# Altium schematic sheet Power.SchDoc
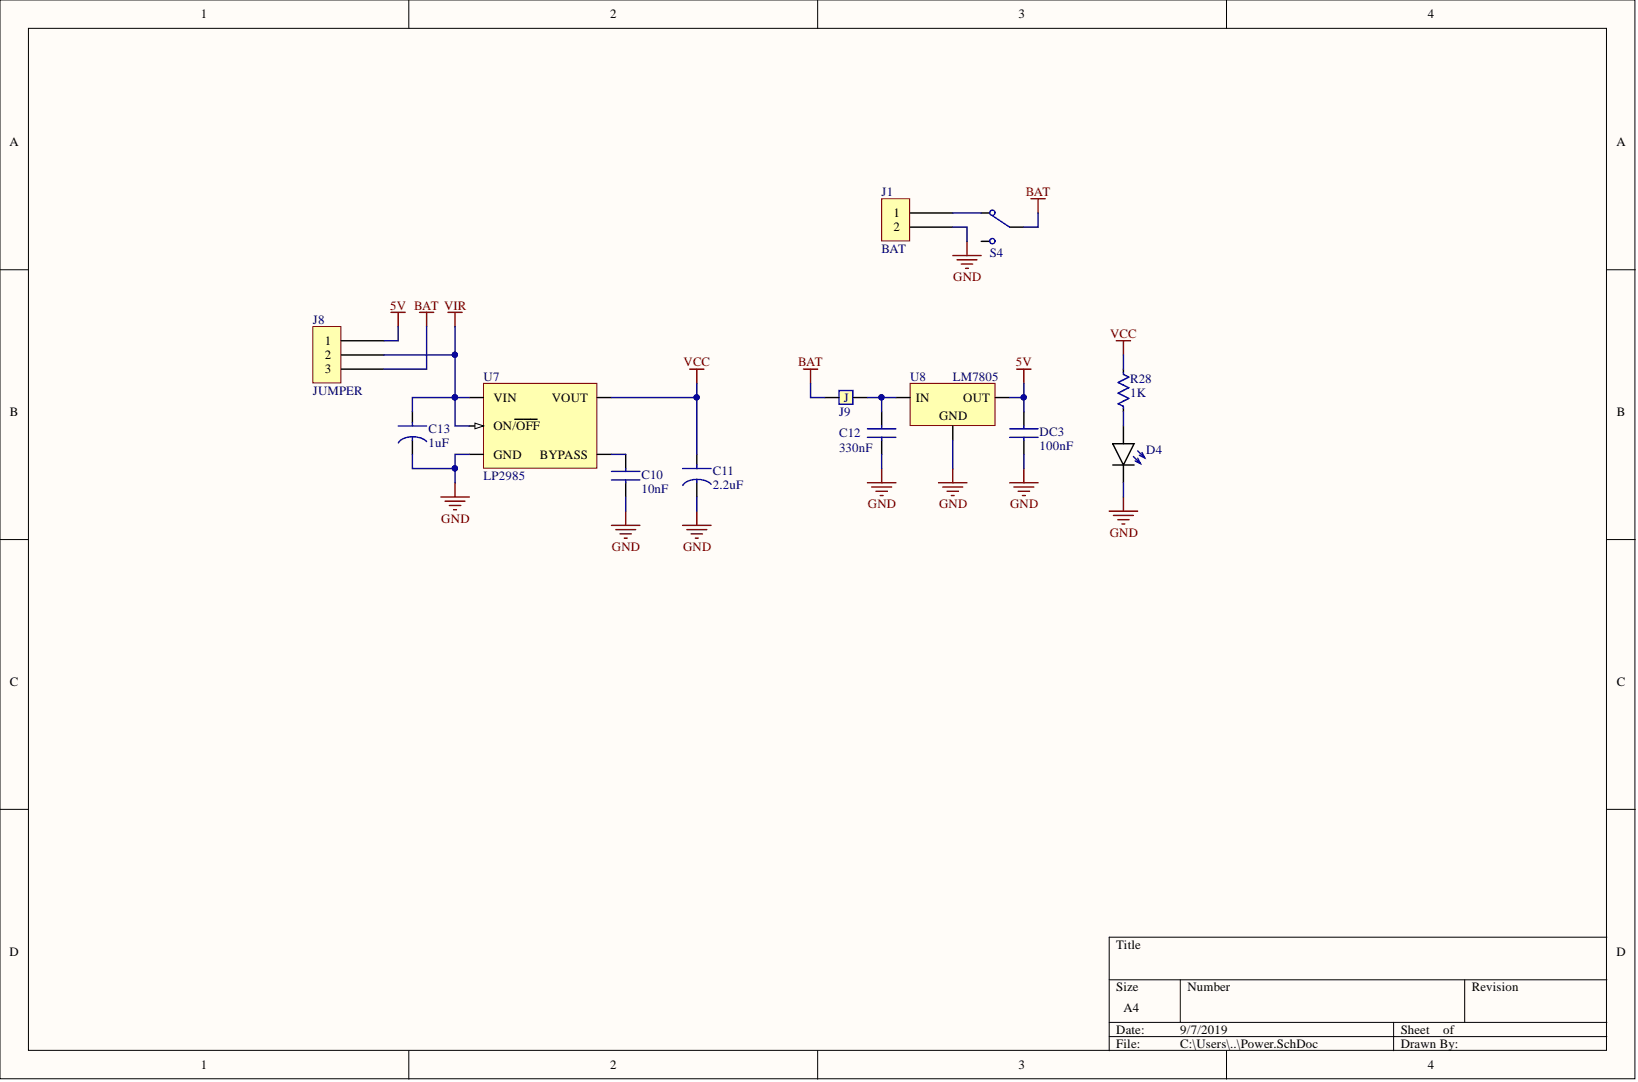
[diagram p0: Power.SchDoc]

## Parts
C10  value 10nF  [C1206]  (hidden comment: CAP-CER)
C11  value 2.2uF  [CAP-ELEC-5x11x2mm]  (hidden comment: CAP-ELEC)
C12  value 330nF  [C1206]  (hidden comment: CAP-CER)
C13  value 1uF  [CAP-ELEC-5x11x2mm]  (hidden comment: CAP-ELEC)
D4  LED  [LED-3MM-RED]  (value not drawn)
DC3  value 100nF  [C1206]  (hidden comment: CAP-CER)
J1  BAT  [HEADER 1x2]
J8  JUMPER  [Header 1x3]
J9  JUMPER  [JUMPER]  (value not drawn)
R28  value 1K  [R1206]  (hidden comment: RES)
S4  SLIDE-SWITCH  [SWITCH]  (value not drawn)
U7  LP2985  [SOT-23-5]
U8  LM7805  [TO-220-FLAT]

## Nets
5V: DC3.2 J8.1 U8.3
BAT: J8.3 J9.2 S4.2
GND: C10.1 C11.2 C12.2 C13.2 D4.K DC3.1 J1.2 U7.2(GND) U8.2
VCC: C11.1 R28.2 U7.5(VOUT)
VIR: C13.1 J8.2 U7.1(VIN) U7.3(ON/OFF)
NetC10_2: C10.2 U7.4(BYPASS)
NetC12_1: C12.1 J9.1 U8.1
NetD4_A: D4.A R28.1
NetJ1_1: J1.1 S4.1

Not connected: S4 3

## Library data of the parts
J1 = Header 1x2: Component Kind: Standard; Library Name: AltiumLibrary.SchLib
J8 = Header 1x3: Component Kind: Standard; Library Name: AltiumLibrary.SchLib
U8 = LM78XX: Component Kind: Standard; Library Name: AltiumLibrary.SchLib
C10 C12 DC3 = CAP-CER: Component Kind: Standard; Library Name: AltiumLibrary.SchLib
C11 C13 = CAP-ELEC: Component Kind: Standard; Library Name: AltiumLibrary.SchLib
D4 = LED: Component Kind: Standard; Library Name: AltiumLibrary.SchLib
J9 = JUMPER: Component Kind: Standard; Library Name: AltiumLibrary.SchLib
R28 = RES: Component Kind: Standard; Library Name: AltiumLibrary.SchLib
S4 = SLIDE-SWITCH: Component Kind: Standard; Library Name: AltiumLibrary.SchLib
U7 = LP2985: Component Kind: Standard; Library Name: AltiumLibrary.SchLib
